# Altium schematic sheet [10] - AMBIENT LIGHT SENSOR.SchDoc
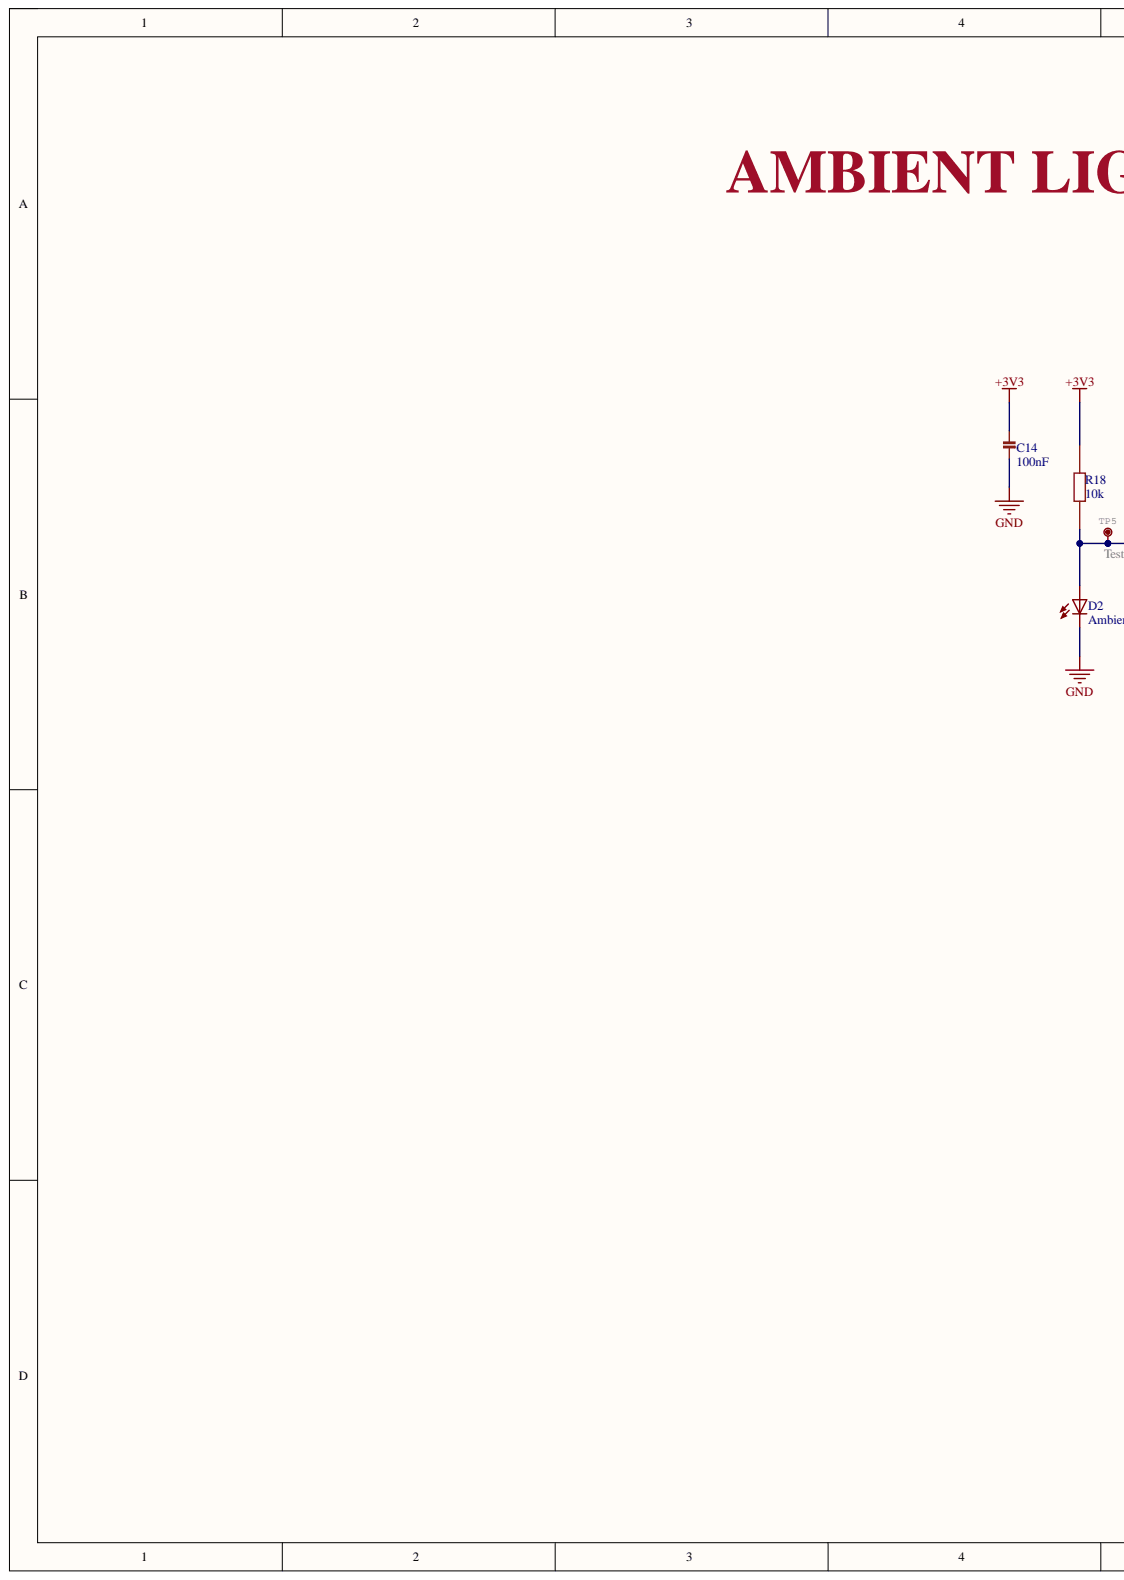
[diagram p0: [10] - AMBIENT LIGHT SENSOR.SchDoc, left]
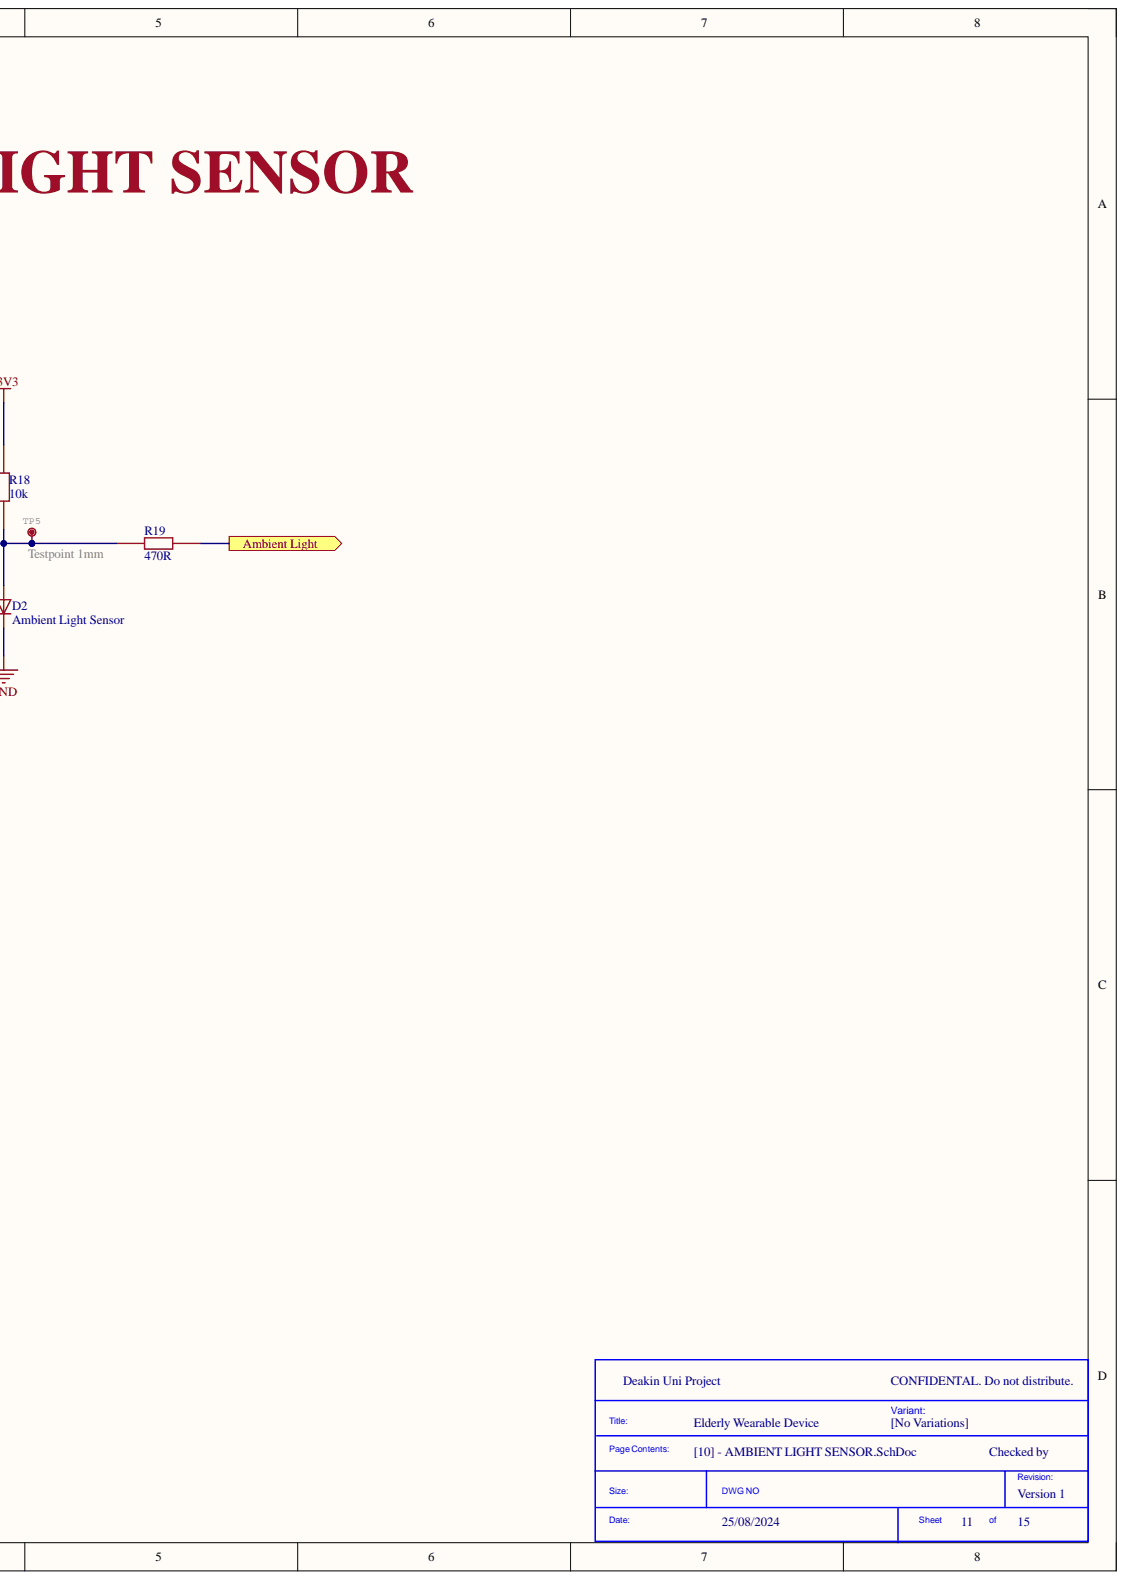
[diagram p1: [10] - AMBIENT LIGHT SENSOR.SchDoc, right]

## Parts
C14  100nF  [C_0402]
D2  Ambient Light Sensor  [Ambient_Light_Sensor]
R18  10k  [R_0603]
R19  470R  [R_0603]
TP5  Testpoint 1mm  [Testpoint_1mm]

## Ports
Ambient Light

## Nets
+3V3: C14.2 R18.2
GND: C14.1 D2.C
Ambient Light: R19.2 ; port Ambient Light
NetD2_A: D2.A R18.1 R19.1 TP5.X

## Library data of the parts
C14 = C_0402_100nF: SMD Capacitor; Manufacturer: Murata Electronics; Manufacturer Part Number: GRM155R71C104KA88J; ALL GOOD: OK_DONE; Component Kind: Standard; Package: 0402; Supplier: Digi-Key; Supplier Part Number: 490-6328-1-ND; Library Name: Elderly_Wearable_Device.SCHLIB
D2 = Ambient Light Sensor: SENSOR PHOTODIODE 850NM RADIAL; Manufacturer: ams-OSRAM USA INC.; Manufacturer Part Number: SFH 203 P; ALL GOOD: OK_DONE; Component Kind: Standard; Package: Radial; Supplier: Digi-Key; Supplier part number: 475-SFH203P-ND; Library Name: Elderly_Wearable_Device.SCHLIB
R18 = R_0603_10k: SMD Resistor; Manufacturer: Yageo; Manufacturer Part Number: RC0603FR-0710KL; ALL GOOD: OK_DONE; Component Kind: Standard; Package: 0603; Supplier: Digi-Key; Supplier Part Number: 311-10.0KHRCT-ND; Library Name: Elderly_Wearable_Device.SCHLIB
R19 = R_0603_470R: SMD Resistor; Manufacturer: Yageo; Manufacturer Part Number: RC0603FR-07470RL; ALL GOOD: OK_DONE; Component Kind: Standard; Package: 0603; Supplier: Digi-Key; Supplier Part Number: 311-470HRCT-ND; Library Name: Elderly_Wearable_Device.SCHLIB
TP5 = Testpoint 1mm: MANUFACTURER: NA; ALL GOOD: OK_DONE; Component Kind: Standard; Description: Testpoint 1mm; Manufacturer Part Number: NA; Package: TP-1.00MM; Supplier: NA; Supplier Part Number: NA; Library Name: Elderly_Wearable_Device.SCHLIB
